# Altium schematic sheet USB.SchDoc(U_USB)
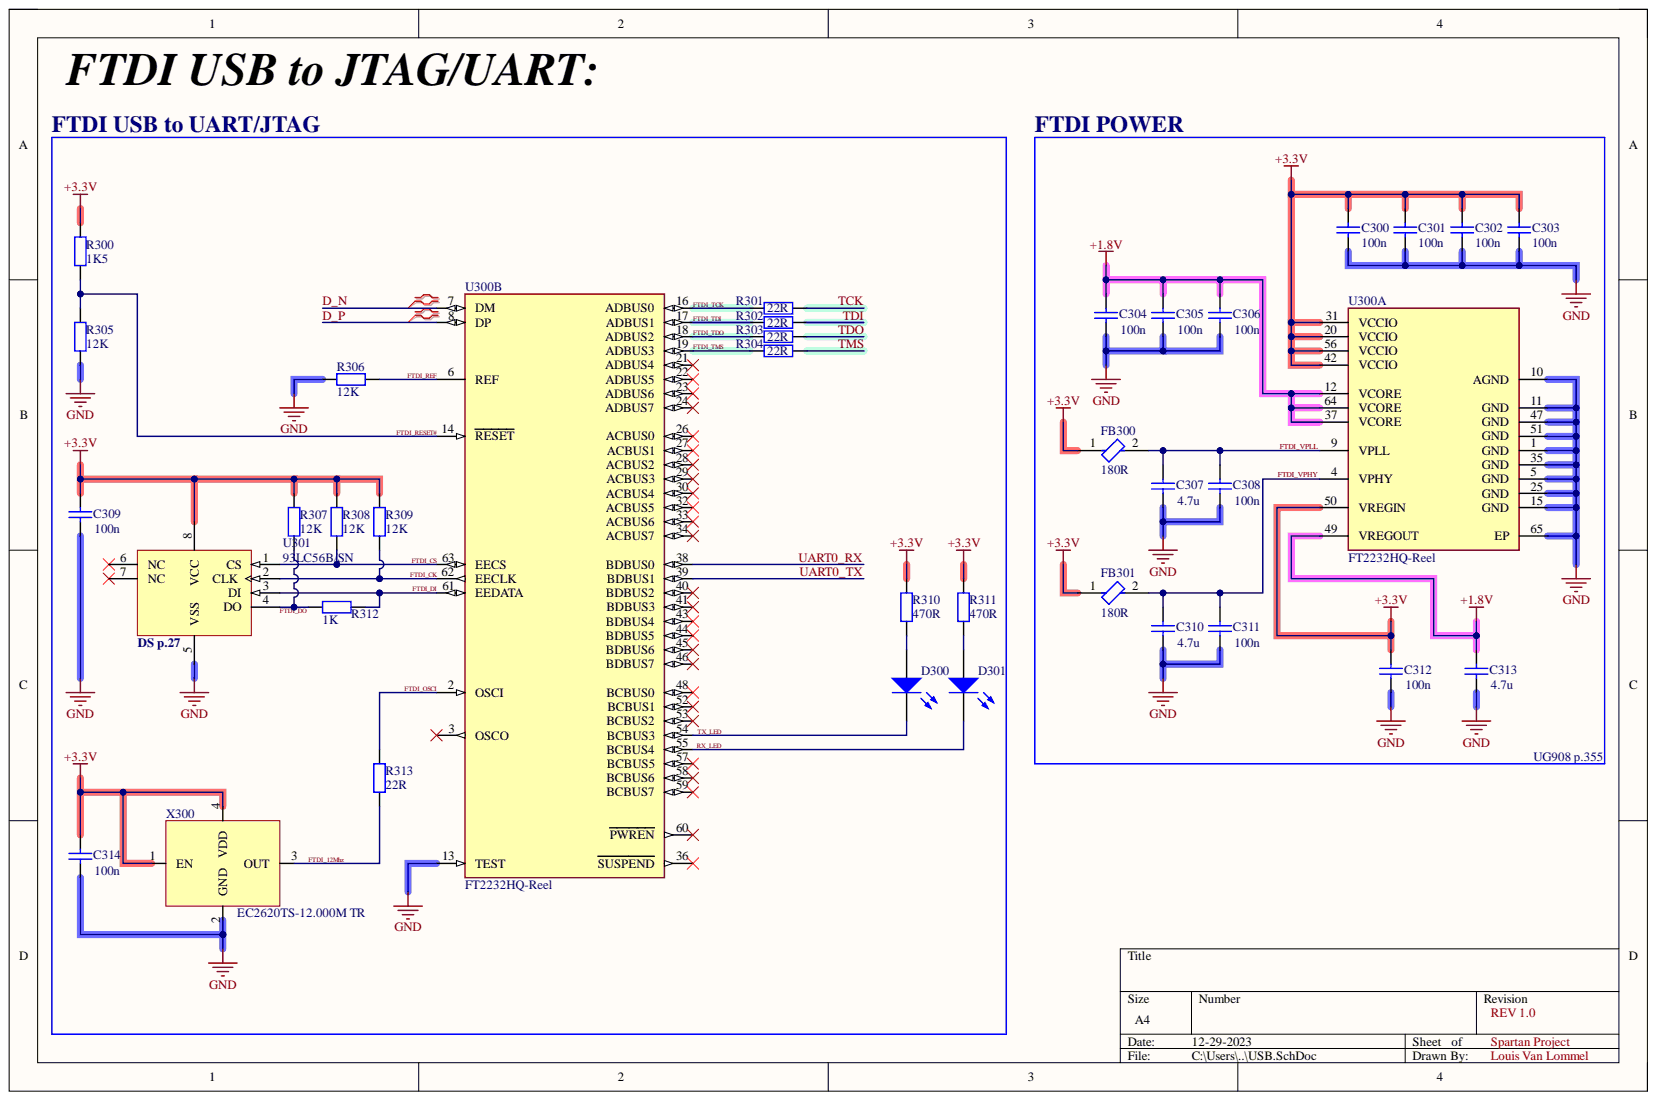
[diagram p0: USB.SchDoc]

## Parts
C300  100n  [CAP_0603]
C301  100n  [CAP_0603]
C302  100n  [CAP_0603]
C303  100n  [CAP_0603]
C304  100n  [CAP_0603]
C305  100n  [CAP_0603]
C306  100n  [CAP_0603]
C307  4.7u  [CAP_0603]
C308  100n  [CAP_0603]
C309  100n  [CAP_0603]
C310  4.7u  [CAP_0603]
C311  100n  [CAP_0603]
C312  100n  [CAP_0603]
C313  4.7u  [CAP_0603]
C314  100n  [CAP_0603]
D300  LED  [LED_0603]  (value not drawn)
D301  LED  [LED_0603]  (value not drawn)
FB300  180R  [CAP_0805]
FB301  180R  [CAP_0805]
R300  1K5  [RES_0603]
R301  22  value 22R  [RES_0603]
R302  22  value 22R  [RES_0603]
R303  22  value 22R  [RES_0603]
R304  22  value 22R  [RES_0603]
R305  12K  [RES_0603]
R306  12K  [RES_0603]
R307  12K  [RES_0603]
R308  12K  [RES_0603]
R309  12K  [RES_0603]
R310  470  value 470R  [RES_0603]
R311  470  value 470R  [RES_0603]
R312  1K  [RES_0603]
R313  22  value 22R  [RES_0603]
U300  FT2232HQ-Reel  [FP-FT2232HQ-Reel-MFG]  (parts A,B)
U301  93LC56B/SN  [SOIC8]
X300  EC2620TS-12.000M TR  [7x5mm Crystal]

## Nets
+1.8V: C304.2 C305.2 C306.2 C313.2 U300.12(VCORE) U300.37(VCORE) U300.49(VREGOUT) U300.64(VCORE)
+3.3V: C300.2 C301.2 C302.2 C303.2 C309.2 C312.2 C314.2 FB300.1 FB301.1 R300.2 R307.1 R308.1 R309.1 R310.2 R311.2 U300.20(VCCIO) U300.31(VCCIO) U300.42(VCCIO) U300.50(VREGIN) U300.56(VCCIO) U301.8(VCC) X300.1(EN) X300.4(VDD)
GND: C300.1 C301.1 C302.1 C303.1 C304.1 C305.1 C306.1 C307.1 C308.1 C309.1 C310.1 C311.1 C312.1 C313.1 C314.1 R305.1 R306.1 U300.1(GND) U300.5(GND) U300.10(AGND) U300.11(GND) U300.13(TEST) U300.15(GND) U300.25(GND) U300.35(GND) U300.47(GND) U300.51(GND) U300.65(EP) U301.5(VSS) X300.2(GND)
D_N: U300.7(DM)
D_P: U300.8(DP)
FTDI_12Mhz: R313.2 X300.3(OUT)
FTDI_CK: R309.2 U300.62(EECLK) U301.2(CLK)
FTDI_CS: R308.2 U300.63(EECS) U301.1(CS)
FTDI_DI: R312.1 U300.61(EEDATA) U301.3(DI)
FTDI_DO: R307.2 R312.2 U301.4(DO)
FTDI_OSCI: R313.1 U300.2(OSCI)
FTDI_REF: R306.2 U300.6(REF)
FTDI_RESET#: R300.1 R305.2 U300.14(RESET)
FTDI_TCK: R301.2 U300.16(ADBUS0)
FTDI_TDI: R302.2 U300.17(ADBUS1)
FTDI_TDO: R303.2 U300.18(ADBUS2)
FTDI_TMS: R304.2 U300.19(ADBUS3)
FTDI_VPHY: C310.2 C311.2 FB301.2 U300.4(VPHY)
FTDI_VPLL: C307.2 C308.2 FB300.2 U300.9(VPLL)
RX_LED: D301.2 U300.55(BCBUS4)
TCK: R301.1
TDI: R302.1
TDO: R303.1
TMS: R304.1
TX_LED: D300.2 U300.54(BCBUS3)
UART0_RX: U300.38(BDBUS0)
UART0_TX: U300.39(BDBUS1)
NetD300_1: D300.1 R310.1
NetD301_1: D301.1 R311.1

Not connected: U300 3(OSCO) 21(ADBUS4) 22(ADBUS5) 23(ADBUS6) 24(ADBUS7) 26(ACBUS0) 27(ACBUS1) 28(ACBUS2) 29(ACBUS3) 30(ACBUS4) 32(ACBUS5) 33(ACBUS6) 34(ACBUS7) 36(SUSPEND) 40(BDBUS2) 41(BDBUS3) 43(BDBUS4) 44(BDBUS5) 45(BDBUS6) 46(BDBUS7) 48(BCBUS0) 52(BCBUS1) 53(BCBUS2) 57(BCBUS5) 58(BCBUS6) 59(BCBUS7) 60(PWREN); U301 6(NC) 7(NC)

## Library data of the parts
C300-C314 = Cap: Capacitor; Component Kind: Standard; LatestRevisionDate: 17-Jul-2002; LatestRevisionNote: Re-released for DXP Platform.; Library Name: Miscellaneous Devices.IntLib; PackageDescription: Radial Cap, Thru-Hole; 2 Leads; 0.3 in Pin Spacing; PackageReference: RAD-0.3; Published: 8-Jun-2000; Signal Integrity: Cap; Simulation: CAP; Publisher: Altium Limited
  C300-C306 C308 C309 C311 C312 C314: Value: 100n
  C307 C310 C313: Value: 4.7u
D300 D301 = LED0: Typical INFRARED GaAs LED; Component Kind: Standard; LatestRevisionDate: 08-Jul-2005; LatestRevisionNote: Mech Layer 1 removed.; Library Name: Miscellaneous Devices.IntLib; PackageDescription: LED; 2 Leads; PackageReference: LED-0; Published: 8-Jun-2000; Simulation: LED0; Publisher: Altium Limited
FB300 FB301 = Ferrite Bead: Chip Ferrite Bead, 0402, 1000Ω @ 100MHz, 0.65Ω, 25%, 300mA; DC Current: 200mA; DC Resistance (DCR): 650mR; DC Resistance-Max: 1R; Impedance: 1kR; Number of Circuits: 1; Shielding: Unshielded; Case Code (Imperial): 0402; Case Code (Metric): 1005; Case/Package: 0402; Component Kind: Standard; Core Material: Ferrite; Current Rating: 300mA; Depth: 0.55mm; Frequency: 100MHz; Height: 0.5mm; Lead Free: Lead Free; Length: 1mm; Library Name: Schematic_Symbols.SchLib; Material: Ferrite; Max Current Rating: 300mA; Max DC Current: 200mA; Max Operating Temperature: 125°C; Military Standard: Not; Min Operating Temperature: -55°C; Number of Lines: 1; Number of Pins: 2; Package Quantity: 1; Packaging: Tape and Reel; Radiation Hardening: No; REACH SVHC: No SVHC; RoHS Compliant: Yes; Series: BLM15AG_SN; Termination: SMD/SMT; Test Frequency: 100MHz; Tolerance: 25%; Width: 0.5mm
R300-R313 = Res2: Resistor; Component Kind: Standard; LatestRevisionDate: 17-Jul-2002; LatestRevisionNote: Re-released for DXP Platform.; Library Name: Miscellaneous Devices.IntLib; PackageDescription: Axial Device, Thru-Hole; 2 Leads; 0.4 in Pin Spacing; PackageReference: AXIAL-0.4; Published: 8-Jun-2000; Signal Integrity: Res; Simulation: RESISTOR; Publisher: Altium Limited
  R300: Value: 1K5
  R305-R309: Value: 12K
  R312: Value: 1K
U300 = CMP-0078-00004-5: IC USB HS DUAL UART/FIFO 64-QFN; Manufacturer: FTDI; Ciiva Ids: 1315829; Data Rate: 480Mbits/s; Import Ts: 1521843541; Interface: I2C,SPI,UART,USB,RS-232,RS-485,RS-422,Other; Max Supply Voltage: 3.63V; Min Supply Voltage: 1.62V; Nominal Supply Current: 5-70mA; Number Of Ports: 1; Standoff Height: 0mm; Usb Standard: USB 2.0; Ambient Temperature Range High: +85°C; Ambient Temperature Range Low: -40°C; Automotive: No; Category: IC; Component Kind: Standard; ComponentLink2Description: Datasheet; ComponentLink2URL: https://www.ftdichip.com/Support/Documents/DataSheets/ICs/DS_FT2232H.pdf; Device Class L1: Integrated Circuits (ICs); Device Class L2: Interface ICs; Device Class L3: USB Interface ICs; Digikey Description: IC USB HS DUAL UART/FIFO 64-QFN; Footprint Url: https://www.ftdichip.com/Support/Documents/TechnicalNotes/TN_166%20FTDI%20Example%20IC%20PCB%20Footprints.pdf; Height: 0.9mm; Ipc Land Pattern Name: QFN50P900X900X85-64; Lead Free: Yes; Library Name: Altium Content Vault; Manufacturer Part Number: FT2232HQ-Reel; Mouser Description: USB Interface IC USB HS to Dual UART/ FIFO/SPI/JTAG/I2C; Octopart: https://octopart.com/ft2232hq-reel-ftdi-19172126; Package: QFN64; Release Date: 1383921489; RoHS: TRUE; Temperature: -40°C to +85°C; Temperature Range High: +85°C; Temperature Range Low: -40°C
U301 = MEM-SEEPROM-93AA46B-P-8: 2K, 128x16-bit, 2.5V Microwire Serial EEPROM, 8-Pin SOIC 150mil, Commercial Temperature; Code_IPC: SOIC127P600-8; Code_JEDEC: MS-012; Component Kind: Standard; DatasheetVersion: revF, May-2008; Library Name: Schematic_Symbols.SchLib; PackageDescription: 8-Lead Plastic Small Outline (SN) - Narrow, 3.90mm Body [SOIC]; PackageReference: SOIC-SN8; PackageVersion: revBB, Aug-2009
X300 = Oscillator: 12Mhz oscillator; Component Kind: Standard; Library Name: Schematic_Symbols.SchLib
